# Altium schematic sheet EEG_schematic.SchDoc(EEG_schematic) (continued)
## Library data of the parts (continued)
Y1 = XTAL: Crystal Oscillator; Manufacturer Part Number: ECS-80-18-30-JGN-TR; Manufacturer: ECS International; Load Capacitance: 18pF; Frequency Tolerance: 20ppm; Frequency Stability: 30ppm; Frequency: 8MHz; ESR (Equivalent Series Resistance): 100R; Width: 0.126inch; Supplier 1: Digi-Key; Stock 1: 5339; Simulation: XTAL; Published: 8-Jun-2000; Pricing 1: 1=0.69, 10=0.607, 50=0.5676, 100=0.5016, 500=0.4752 (USD); Packaging: Tape and Reel; PackageReference: R38; PackageDescription: Crystal, Thru-Hole; 2 Leads; Body 3.1 x 8.2 mm (Dia.xH); Mount: Surface Mount; Min Operating Temperature: -40°C; Max Operating Temperature: 85°C; Library Name: Miscellaneous Devices.IntLib; Length: 0.197inch; LatestRevisionNote: Re-released for DXP Platform.; LatestRevisionDate: 17-Jul-2002; Height: 0.055inch; ComponentLink3URL: http://www.ecsxtal.com/store/pdf/ecx-53r.pdf?src-supplier=Digi-Key; ComponentLink3Description: http://www.ecsxtal.com/store/pdf/ecx-53r.pdf?src-supplier=Digi-Key; ComponentLink2URL: http://www.ecsxtal.com/store/pdf/partnumber4.pdf?src-supplier=Digi-Key; ComponentLink2Description: http://www.ecsxtal.com/store/pdf/partnumber4.pdf?src-supplier=Digi-Key; ComponentLink1URL: http://www.mouser.com/ds/2/122/ecx-53r-17605.pdf?src-supplier=Mouser; ComponentLink1Description: http://www.mouser.com/ds/2/122/ecx-53r-17605.pdf?src-supplier=Mouser; Component Kind: Standard; Case/Package: SMD/SMT; Publisher: Altium Limited
